# Altium schematic sheet LEKCJA1.SchDoc(LEKCJA1)
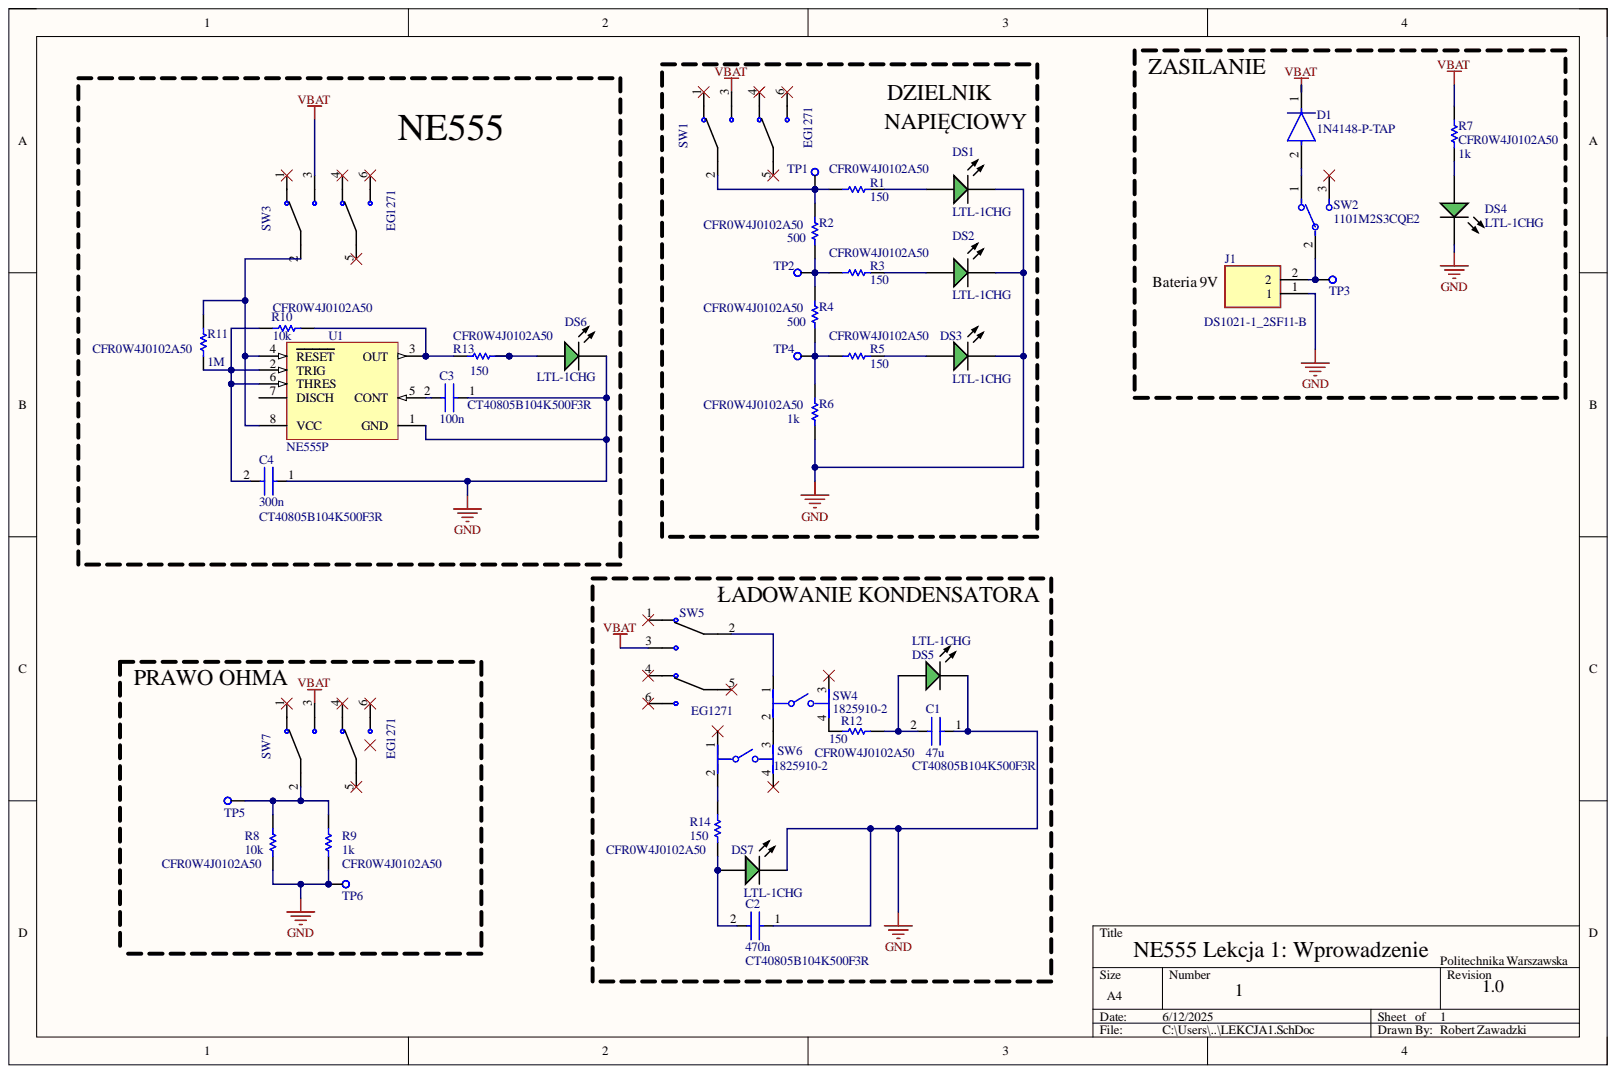
[diagram p0: LEKCJA1.SchDoc]

## Parts
C1  CT40805B104K500F3R  Capacitance: 47u  [THT_RADIAL_CAP_P5.0mm]
C2  CT40805B104K500F3R  Capacitance: 470n  [THT_RADIAL_CAP_P5.0mm]
C3  CT40805B104K500F3R  Capacitance: 100n  [THT_RADIAL_CAP_P5.0mm]
C4  CT40805B104K500F3R  Capacitance: 300n  [THT_RADIAL_CAP_P5.0mm]
D1  1N4148-P-TAP  [FP-SB-V-3906_04-031_4-MFG]
DS1  LTL-1CHG  [LTST-LTL-1CHG-2]
DS2  LTL-1CHG  [LTST-LTL-1CHG-2]
DS3  LTL-1CHG  [LTST-LTL-1CHG-2]
DS4  LTL-1CHG  [LTST-LTL-1CHG-2]
DS5  LTL-1CHG  [LTST-LTL-1CHG-2]
DS6  LTL-1CHG  [LTST-LTL-1CHG-2]
DS7  LTL-1CHG  [LTST-LTL-1CHG-2]
J1  DS1021-1_2SF11-B  [HDRV2W64P0X254_1X2_508X254X588P]
R1  CFR0W4J0102A50  [THT_AXIAL_RES_D2.5mm_L6.8mm]
R2  CFR0W4J0102A50  [THT_AXIAL_RES_D2.5mm_L6.8mm]
R3  CFR0W4J0102A50  [THT_AXIAL_RES_D2.5mm_L6.8mm]
R4  CFR0W4J0102A50  [THT_AXIAL_RES_D2.5mm_L6.8mm]
R5  CFR0W4J0102A50  [THT_AXIAL_RES_D2.5mm_L6.8mm]
R6  CFR0W4J0102A50  Resistance: 1k  [THT_AXIAL_RES_D2.5mm_L6.8mm]
R7  CFR0W4J0102A50  Resistance: 1k  [THT_AXIAL_RES_D2.5mm_L6.8mm]
R8  CFR0W4J0102A50  Resistance: 10k  [THT_AXIAL_RES_D2.5mm_L6.8mm]
R9  CFR0W4J0102A50  Resistance: 1k  [THT_AXIAL_RES_D2.5mm_L6.8mm]
R10  CFR0W4J0102A50  Resistance: 10k  [THT_AXIAL_RES_D2.5mm_L6.8mm]
R11  CFR0W4J0102A50  Resistance: 1M  [THT_AXIAL_RES_D2.5mm_L6.8mm]
R12  CFR0W4J0102A50  [THT_AXIAL_RES_D2.5mm_L6.8mm]
R13  CFR0W4J0102A50  [THT_AXIAL_RES_D2.5mm_L6.8mm]
R14  CFR0W4J0102A50  [THT_AXIAL_RES_D2.5mm_L6.8mm]
SW1  EG1271  [*SW_EG1271]
SW2  1101M2S3CQE2  [FP-1101M2S3CQE2-MFG]
SW3  EG1271  [*SW_EG1271]
SW4  1825910-2  [FP-1825910-2-0]
SW5  EG1271  [*SW_EG1271]
SW6  1825910-2  [FP-1825910-2-0]
SW7  EG1271  [*SW_EG1271]
TP1  Testpoint  [TESTPOINT_PAD_D1.5mm]  (value not drawn)
TP2  Testpoint  [TESTPOINT_PAD_D1.5mm]  (value not drawn)
TP3  Testpoint  [TESTPOINT_PAD_D1.5mm]  (value not drawn)
TP4  Testpoint  [TESTPOINT_PAD_D1.5mm]  (value not drawn)
TP5  Testpoint  [TESTPOINT_PAD_D1.5mm]  (value not drawn)
TP6  Testpoint  [TESTPOINT_PAD_D1.5mm]  (value not drawn)
U1  NE555P  [P0008A]

## Nets
GND: C1.1 C2.1 C3.1 C4.1 DS1.2 DS2.2 DS3.2 DS4.2 DS5.2 DS6.2 DS7.2 J1.1 R6.2 R8.2 R9.2 TP6.1 U1.1(GND)
VBAT: D1.1 R7.1 SW1.3 SW3.3 SW5.3 SW7.3
NetC1_2: C1.2 DS5.1 R12.2
NetC2_2: C2.2 DS7.1 R14.1
NetC3_2: C3.2 U1.5(CONT)
NetC4_2: C4.2 R10.1 R11.1 U1.2(TRIG) U1.6(THRES)
NetD1_2: D1.2 SW2.1
NetDS1_1: DS1.1 R1.2
NetDS2_1: DS2.1 R3.2
NetDS3_1: DS3.1 R5.2
NetDS4_1: DS4.1 R7.2
NetDS6_1: DS6.1 R13.2
NetJ1_2: J1.2 SW2.2 TP3.1
NetR1_1: R1.1 R2.1 SW1.2 TP1.1
NetR2_2: R2.2 R3.1 R4.1 TP2.1
NetR4_2: R4.2 R5.1 R6.1 TP4.1
NetR8_1: R8.1 R9.1 SW7.2 TP5.1
NetR10_2: R10.2 R13.1 U1.3(OUT)
NetR11_2: R11.2 SW3.2 U1.4(RESET) U1.8(VCC)
NetR12_1: R12.1 SW4.4
NetR14_2: R14.2 SW6.2
NetSW4_1: SW4.1 SW5.2
NetSW4_2: SW4.2 SW6.3

Not connected: SW1 1 4 5 6; SW2 3; SW3 1 4 5 6; SW4 3; SW5 1 4 5 6; SW6 1 4; SW7 1 4 5 6; U1 7(DISCH)

## Library data of the parts
C1-C4 = C-THT-MLCC-5mm: Manufacturer: SR PASSIVES; Voltage: 50V; Component Kind: Standard; Library Name: RLC.SchLib
D1 = CMP-00030-43969657-1: 1N4148-P-TAP Switching Diode Genpurp Do35100V, 1V@10Ma, 4Ns, Ifmax=300Ma; Average Rectified Current: 2A; Capacitance: 4pF; Element Configuration: Single; Forward Current: 150mA; Forward Voltage: 1V; Max Junction Temperature (Tj): 175°C; Max Power Dissipation: 500mW; Max Repetitive Reverse Voltage (Vrrm): 100V; Max Reverse Leakage Current: 5µA; Max Reverse Voltage (DC): 75V; Recovery Time: 8ns; Case/Package: DO-35-2; Component Kind: Standard; Contact Plating: Tin; Height: 1.7mm; Library Name: Altium Content Vault; Max Forward Surge Current (Ifsm): 2A; Max Operating Temperature: 175°C; Max Surge Current: 2A; Min Operating Temperature: -65°C; Mount: Through Hole; NexarPartId: 43969657; Number of Pins: 2; Peak Non-Repetitive Surge Current: 2A; Peak Reverse Current: 5µA; Reverse Recovery Time: 8ns; Reverse Voltage: 75V; RoHS: Compliant; Schedule B: 8541100070, 8541100070
DS1-DS7 = CMP-1674-00014-1: LITE-ON Electronic, INC, 120 mA, 100 mW, 5 V, -55 to 100 degC, 2-Pin THD, RoHS, Bulk; Case\Package: QFN; Interface: I2C; Max Supply Voltage: 3.6 V; Min Supply Voltage: 2.5 V; Component Kind: Standard; ComponentLink1Description: Manufacturer URL; ComponentLink1URL: http://www.us.liteon.com/; ComponentLink2Description: Datasheet; ComponentLink2URL: http://datasheet.octopart.com/LTL-1CHG-Lite-On-datasheet-143841.pdf; DatasheetVersion: Mar-00; Library Name: Altium Content Vault; Max Operating Temperature: 85 degC; Min Operating Temperature: -40 degC; Package Quantity: 5000; PackageDescription: 2-Pin Through Hole Device, Dia 3.8 mm; PackageReference: LTL-1CHG; Packaging: Cut Tape; Pins: 24; REACH SVHC: No SVHC; RoHSCompliant: true
J1 = DS1021-1_2SF11-B: Connector; Manufacturer_Name: Connfly; Manufacturer_Part_Number: DS1021-1*2SF11-B; Component Kind: Standard; Datasheet Link: https://www.tme.com/Document/cc301e58a5da78cb543a94b5a5b7f06a/DS1021.pdf; Height: 5.88mm; Library Name: SamacSys.SchLib
R1 R3 R5 R12-R14 = R-THT-250mW: Manufacturer: ROYALOHM; Power: 250mW; Resistance: 150; Component Kind: Standard; Library Name: RLC.SchLib
R2 R4 = R-THT-250mW: Manufacturer: ROYALOHM; Power: 250mW; Resistance: 500; Component Kind: Standard; Library Name: RLC.SchLib
R6-R11 = R-THT-250mW: Manufacturer: ROYALOHM; Power: 250mW; Component Kind: Standard; Library Name: RLC.SchLib
SW1 SW3 SW5 SW7 = EG1271: Manufacturer: E-SWITCH; Component Kind: Standard; Library Name: EG1271.SchLib; Part Number: EG1271
SW2 = CMP-10038-000019-1: SWITCH SLIDE SPDT 6A 120V; Actuator Color: Black; Actuator Length: 5.08mm; Actuator Material: Nylon; Actuator Type: Standard; Approvals: UL; Body Material: Stainless Steel; Contact Resistance: 10mR; Electrical Life: 40000Cycles; Insulation Resistance: 1GR; Max Voltage Rating (AC): 250V; Max Voltage Rating (DC): 28V; Number of Poles: 1; Number of Positions: 3; Switch Function: On-On; Switch Travel: 2.16mm; Component Kind: Standard; Contact Current Rating: 6A; Contact Material: Copper; Contact Plating: Silver; Current Rating: 6A; Depth: 6.6mm; Flammability Rating: UL94 V-0; Housing Material: Stainless Steel; Lead Free: Lead Free; Length: 12.7mm; Library Name: Altium Content Vault; Max Current Rating: 6A; Max Operating Temperature: 65°C; Min Operating Temperature: -30°C; Mount: Through Hole; Orientation: Vertical; Package Quantity: 1; Packaging: Bulk; REACH SVHC: No SVHC; Series: 1000; Termination: PC Pins; Throw Configuration: SPDT; Voltage Rating (AC): 120V; Voltage Rating (DC): 28V
SW4 SW6 = CMP-03407-000004-1: SWITCH TACTILE SPST-NO 0.05A 24V; Manufacturer: TE; Contact Current Rating: 50mA; Contact Resistance: 100mΩ; Electromechanical Life: 100000Cycles; Operating Force: 160gf; Automotive: No; Category: Switch; Component Kind: Standard; ComponentLink2Description: Datasheet; ComponentLink2URL: https://www.te.com/commerce/DocumentDelivery/DDEController?Action=showdoc&DocId=Customer+Drawing%7F1825910%7FC10%7Fpdf%7FEnglish%7FENG_CD_1825910_C10.pdf%7F1825910-2; Device Class L1: Electromechanical; Device Class L2: Switches; Device Class L3: Tactile Switches; Digikey Description: SWITCH TACTILE SPST-NO 0.05A 24V; Height: 4.6mm; Lead Free: Yes; Library Name: Altium Content Vault; Manufacturer Part Number: 1825910-2; Mount: Through Hole; Octopart: https://octopart.com/1825910-2-te+connectivity-42270502; Package: 0; RoHS: TRUE; Temperature: -35°C to +85°C; Temperature Range High: +85°C; Temperature Range Low: -35°C; Throw Configuration: SPST-NO; Voltage Rating Dc: 24V
TP1-TP6 = Testpoint-D1.5mm: Component Kind: Standard; Library Name: Misc.SchLib
U1 = CMP-2000-04954-1: Precision Timer, 4.5 to 16 V, 0 to 70 degC, 8-Pin DIP, Pb-Free, Tube; Manufacturer: TexasInstruments; Maximum High Level Output Current: -200mA; Maximum Low Level Output Current: 200mA; Number of Internal Timers: 1; Operating Supply Voltage: 5; Rad Hard: No; Category: Timer; Component Kind: Standard; Datasheet URL: http://www.ti.com/general/docs/lit/getliterature.tsp?genericPartNumber=NE555&fileType=pdf; Datasheet Version: Rev. I, 09/2014; Library Name: Altium Content Vault; Manufacturer URL: http://www.ti.com/; Package: 8PDIP; Package Description: 8-Pin Double In-line Package, Body 9.59 x 6.35 mm, Pitch 2.54 mm; Package Reference: P8; Package URL: http://www.ti.com/lit/ml/mpdi001b/mpdi001b.pdf; Packaging: Rail/Tube; Type: Standard
